# Altium schematic sheet RP5_Left_Board.SchDoc(RP5_Left_Board)
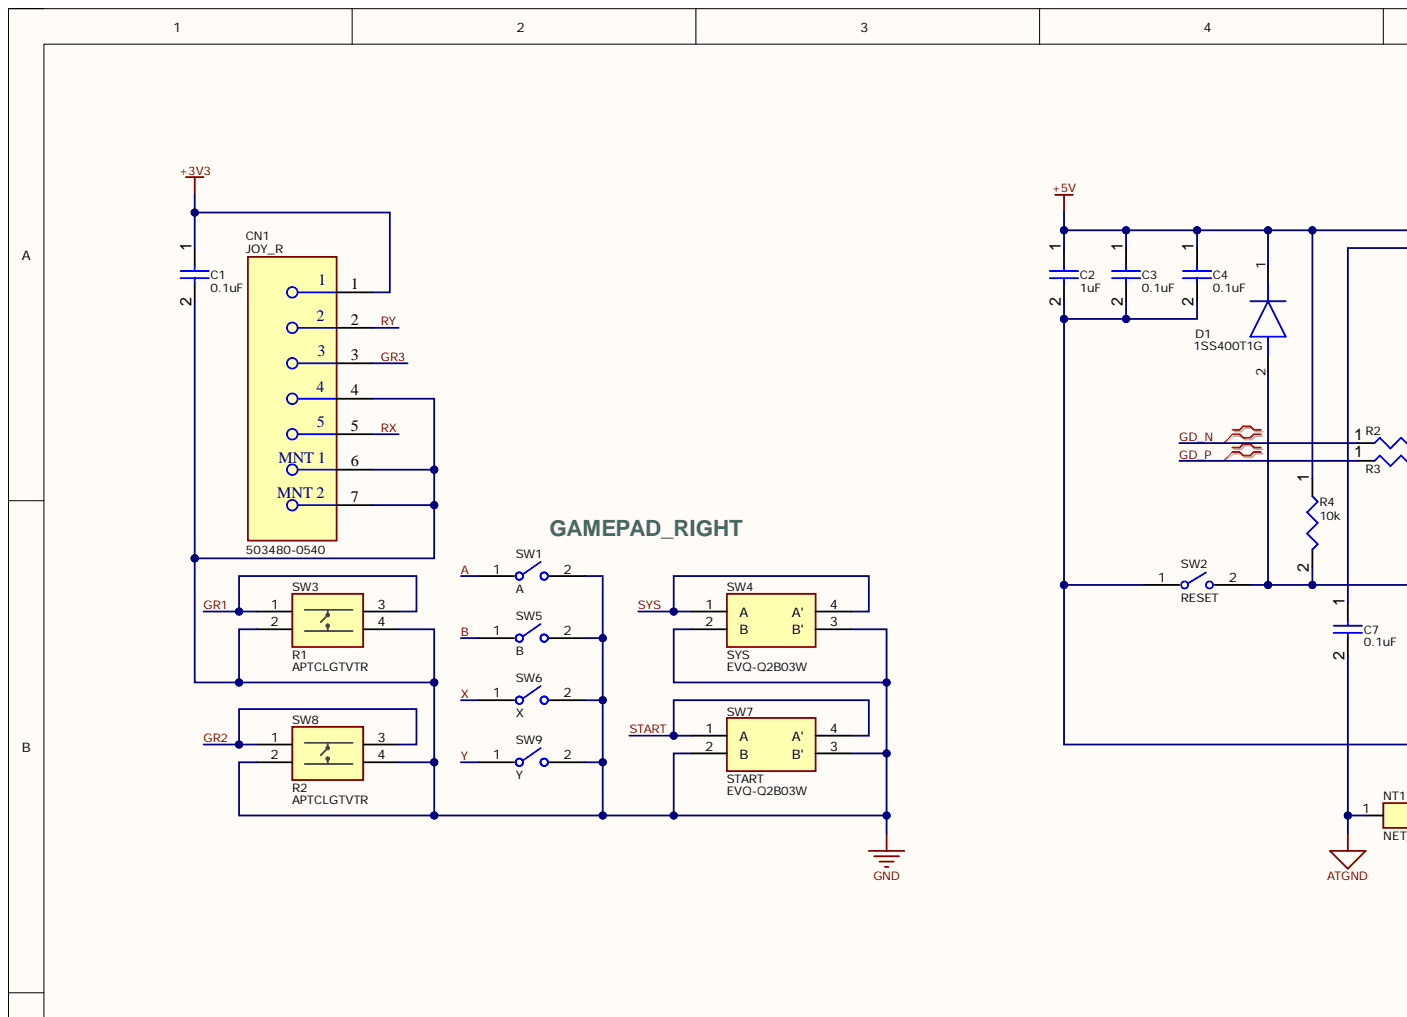
[diagram p0: RP5_Left_Board.SchDoc, top left]
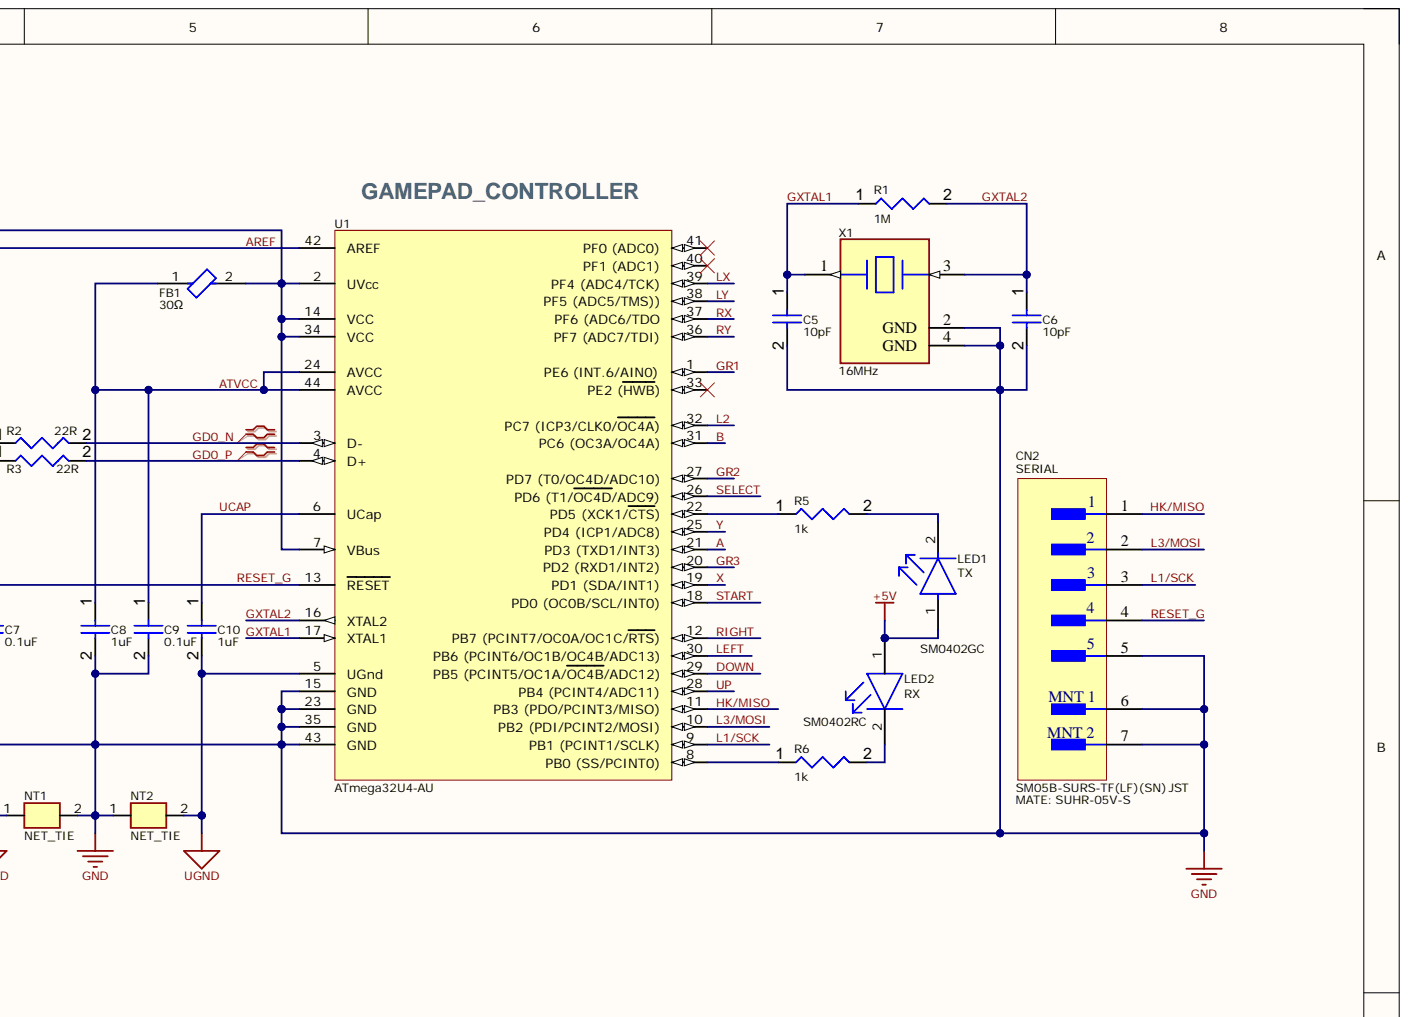
[diagram p1: RP5_Left_Board.SchDoc, top right]
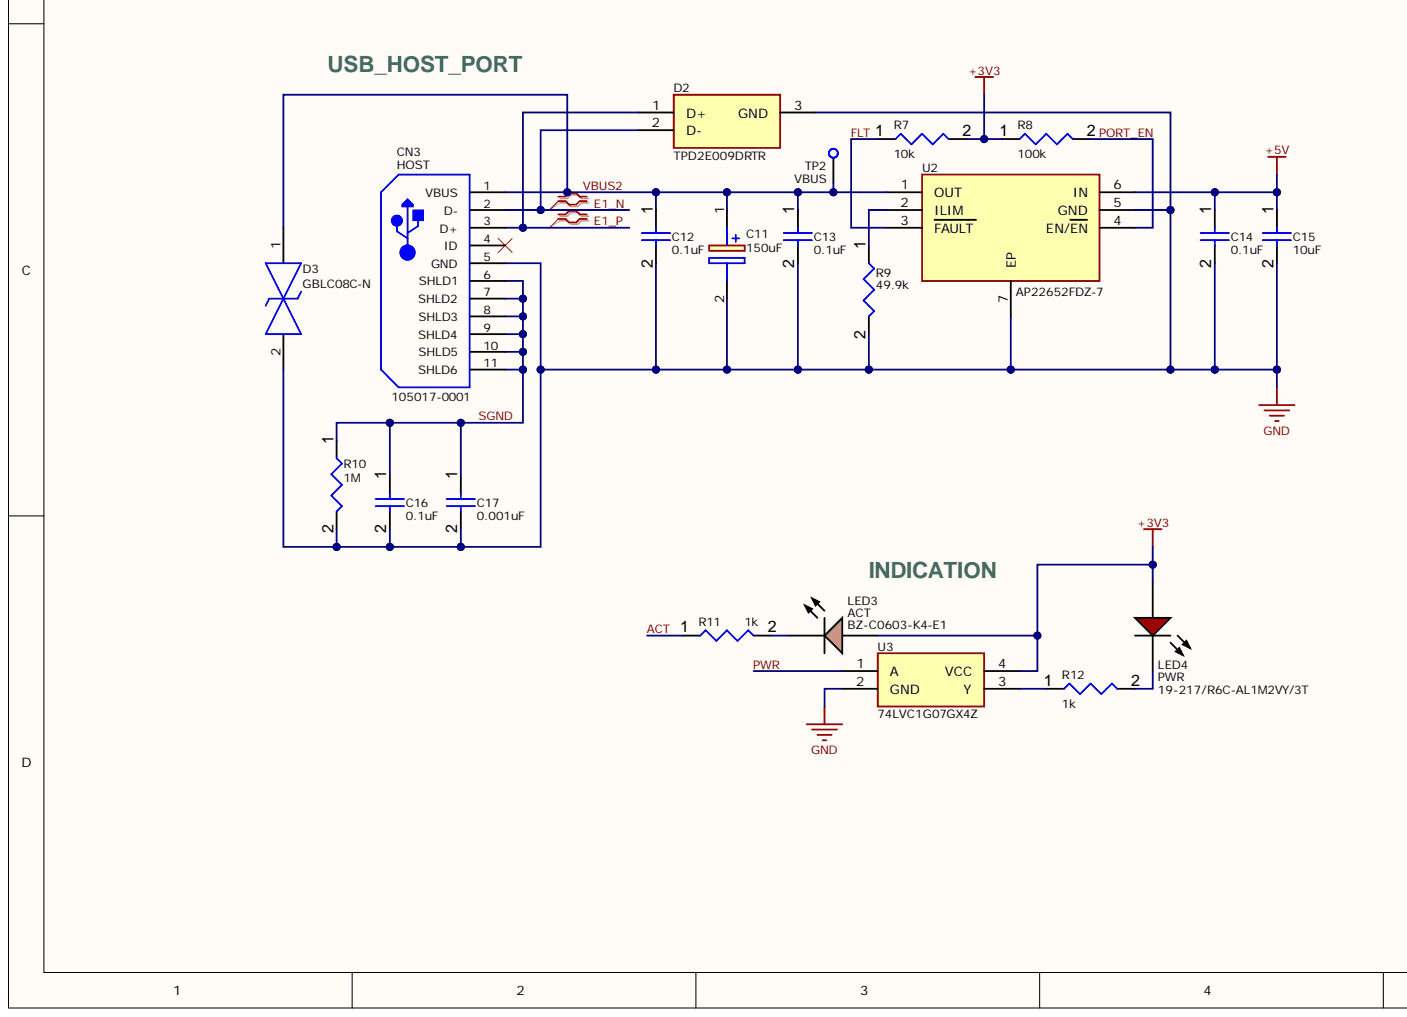
[diagram p2: RP5_Left_Board.SchDoc, bottom left]
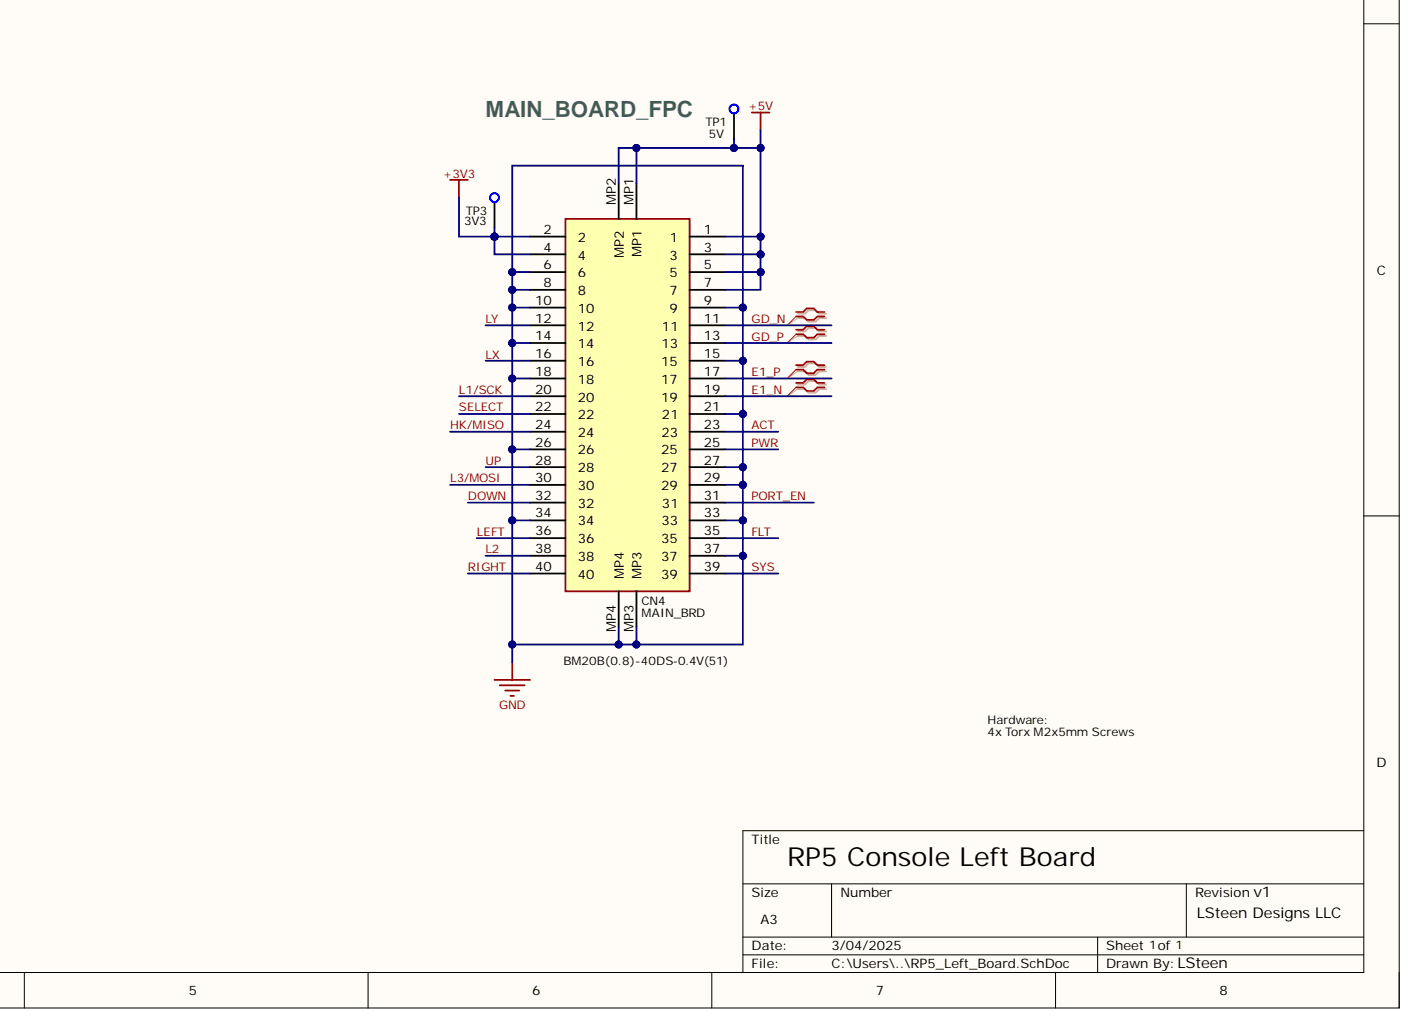
[diagram p3: RP5_Left_Board.SchDoc, bottom right]

## Parts
C1  0.1uF  [CAPC1005X56X25LL10T15]
C2  1uF  [CAPC0603(1608)100_L]
C3  0.1uF  [CAPC1005X56X25LL10T15]
C4  0.1uF  [CAPC1005X56X25LL10T15]
C5  10pF  [CAPC1005X56X25LL10T15]
C6  10pF  [CAPC1005X56X25LL10T15]
C7  0.1uF  [CAPC1005X56X25LL10T15]
C8  1uF  [CAPC0603(1608)100_L]
C9  0.1uF  [CAPC1005X56X25LL10T15]
C10  1uF  [CAPC0603(1608)100_L]
C11  150uF  [CAPPM7344X310N]
C12  0.1uF  [CAPC1005X56X25LL10T15]
C13  0.1uF  [CAPC1005X56X25LL10T15]
C14  0.1uF  [CAPC1005X56X25LL10T15]
C15  10uF  [CAPC0805(2012)145_L]
C16  0.1uF  [CAPC1005X56X25LL10T15]
C17  0.001uF  value 0.1uF  [CAPC1005X56X25LL10T15]
CN1  JOY_R  [FP-503480-0540-MFG]
CN2  SERIAL  [FP-SM05B-SURS-TF_LF_SN-MFG]
CN3  HOST  [105017-0001]
CN4  MAIN_BRD  [BM20B0840DS04V51]
D1  1SS400T1G  [SODFL1608X70N]
D2  TPD2E009DRTR  [SOTFL35P100X50-3N]
D3  GBLC08C-N  [SOD-323_L1.8-W1.3-LS2.5-BI]
FB1  30Ω  [FP-MH2029-MFG]
LED1  TX  [FP-SM0402GC-MFG]
LED2  RX  [FP-SM0402RC-MFG]
LED3  ACT  [LED_0603]
LED4  PWR  Manufacturer Part Number: 19-217/R6C-AL1M2VY/3T  [EVRL-0603-2_V]
NT1  NET_TIE  [NET_TIE1]
NT2  NET_TIE  [NET_TIE1]
R1  1M  [RESC0402(1005)_L]
R2  22R  [RESC0402(1005)_L]
R3  22R  [RESC0402(1005)_L]
R4  10k  [RESC0402(1005)_L]
R5  1k  [RESC0402(1005)_L]
R6  1k  [RESC0402(1005)_L]
R7  10k  [RESC0402(1005)_L]
R8  100k  [RESC0402(1005)_L]
R9  49.9k  [RESC0402(1005)_L]
R10  1M  [RESC0402(1005)_L]
R11  1k  [RESC0402(1005)_L]
R12  1k  [RESC0402(1005)_L]
SW1  A  [L4.0-W4.0-CNDV-PAD]
SW2  RESET  [FP-B3U-1000P-MFG]
SW3  R1  [APTCLGTVTR]
SW4  SYS  [EVQQ2]
SW5  B  [L4.0-W4.0-CNDV-PAD]
SW6  X  [L4.0-W4.0-CNDV-PAD]
SW7  START  [EVQQ2]
SW8  R2  Manufacturer_Part_Number: APTCLGTVTR  [APTCLGTVTR_No_Holes]
SW9  Y  [L4.0-W4.0-CNDV-PAD]
TP1  5V  [TP]  (no BOM)
TP2  VBUS  [TP]  (no BOM)
TP3  3V3  [TP]  (no BOM)
U1  ATmega32U4-AU  [44ML_M]
U2  AP22652FDZ-7  [SON65P200X200X80-7N-D]
U3  74LVC1G07GX4Z  [74LVC1G07GX4Z]
X1  16MHz  Load Capacitance: 10pF  [FP-ABM8G-MFG]

## Nets
+3V3: C1.1 CN1.1 CN4.2 CN4.4 LED3.1 LED4.1 R7.2 R8.1 TP3.1 U3.4(VCC)
+5V: C2.1 C3.1 C4.1 C14.1 C15.1 CN4.1 CN4.3 CN4.5 CN4.7 CN4.MP1 CN4.MP2 D1.1 FB1.2 LED1.1 LED2.1 R4.1 TP1.1 U1.2(UVcc) U1.7(VBus) U1.14(VCC) U1.34(VCC) U2.6(IN)
ATGND: C7.2 NT1.1
GND: C1.2 C2.2 C3.2 C4.2 C5.2 C6.2 C8.2 C9.2 C11.2 C12.2 C13.2 C14.2 C15.2 C16.2 C17.2 CN1.4 CN1.6 CN1.7 CN2.5 CN2.6 CN2.7 CN3.5(GND) CN4.6 CN4.8 CN4.9 CN4.10 CN4.14 CN4.15 CN4.18 CN4.21 CN4.26 CN4.27 CN4.29 CN4.33 CN4.34 CN4.37 CN4.MP3 CN4.MP4 D2.3 D3.2 NT1.2 NT2.1 R9.2 R10.2 SW1.2 SW2.1 SW3.2 SW3.4 SW4.2(B) SW4.3(B') SW5.2 SW6.2 SW7.2(B) SW7.3(B') SW8.2 SW8.4 SW9.2 U1.15(GND) U1.23(GND) U1.35(GND) U1.43(GND) U2.5(GND) U2.7(EP) U3.2(GND) X1.2(GND) X1.4(GND)
UGND: C10.2 NT2.2 U1.5(UGnd)
A: SW1.1 U1.21(PD3_(TXD1/INT3))
ACT: CN4.23 R11.1
AREF: C7.1 U1.42(AREF)
ATVCC: C8.1 C9.1 FB1.1 U1.24(AVCC) U1.44(AVCC)
B: SW5.1 U1.31(PC6_(OC3A/OC4A))
DOWN: CN4.32 U1.29
E1_N: CN3.2(D-) CN4.19 D2.2
E1_P: CN3.3(D+) CN4.17 D2.1
FLT: CN4.35 R7.1 U2.3(FAULT)
GD0_N: R2.2 U1.3(D-)
GD0_P: R3.2 U1.4(D+)
GD_N: CN4.11 R2.1
GD_P: CN4.13 R3.1
GR1: SW3.1 SW3.3 U1.1(PE6_(INT.6/AIN0))
GR2: SW8.1 SW8.3 U1.27(PD7_(T0/OC4D/ADC10))
GR3: CN1.3 U1.20(PD2_(RXD1/INT2))
GXTAL1: C5.1 R1.1 U1.17(XTAL1) X1.1
GXTAL2: C6.1 R1.2 U1.16(XTAL2) X1.3
HK/MISO: CN2.1 CN4.24 U1.11(PB3_(PDO/PCINT3/MISO))
L1/SCK: CN2.3 CN4.20 U1.9(PB1_(PCINT1/SCLK))
L2: CN4.38 U1.32(PC7_(ICP3/CLK0/OC4A))
L3/MOSI: CN2.2 CN4.30 U1.10(PB2_(PDI/PCINT2/MOSI))
LEFT: CN4.36 U1.30(PB6_(PCINT6/OC1B/OC4B/ADC13))
LX: CN4.16 U1.39(PF4_(ADC4/TCK))
LY: CN4.12 U1.38(PF5_(ADC5/TMS)))
PORT_EN: CN4.31 R8.2 U2.4(EN/EN)
PWR: CN4.25 U3.1(A)
RESET_G: CN2.4 D1.2 R4.2 SW2.2 U1.13(RESET)
RIGHT: CN4.40 U1.12
RX: CN1.5 U1.37(PF6_(ADC6/TDO)
RY: CN1.2 U1.36(PF7_(ADC7/TDI))
SELECT: CN4.22 U1.26(PD6_(T1/OC4D/ADC9))
SGND: C16.1 C17.1 CN3.6(SHLD1) CN3.7(SHLD2) CN3.8(SHLD3) CN3.9(SHLD4) CN3.10(SHLD5) CN3.11(SHLD6) R10.1
START: SW7.1(A) SW7.4(A') U1.18(PD0_(OC0B/SCL/INT0))
SYS: CN4.39 SW4.1(A) SW4.4(A')
UCAP: C10.1 U1.6(UCap)
UP: CN4.28 U1.28(PB4_(PCINT4/ADC11))
VBUS2: C11.1 C12.1 C13.1 CN3.1(VBUS) D3.1 TP2.1 U2.1(OUT)
X: SW6.1 U1.19(PD1_(SDA/INT1))
Y: SW9.1 U1.25(PD4_(ICP1/ADC8))
NetLED1_2: LED1.2 R5.2
NetLED2_2: LED2.2 R6.2
NetLED3_2: LED3.2 R11.2
NetLED4_2: LED4.2 R12.2
NetR5_1: R5.1 U1.22(PD5_(XCK1/CTS))
NetR6_1: R6.1 U1.8(PB0_(SS/PCINT0))
NetR9_1: R9.1 U2.2(ILIM)
NetR12_1: R12.1 U3.3(Y)

Not connected: CN3 4(ID); U1 33(PE2_(HWB)) 40(PF1_(ADC1)) 41(PF0_(ADC0))

## Library data of the parts
C1 C3 C4 C7 C9 C12-C14 C16 C17 = CMP-001-00001-6: Chip Capacitor, 0.1 uF, +/- 5%, 16 V, 0402 (1005 Metric); Capacitor Type: Ceramic; Voltage Rating: 16V; Case/Package: 0402; Component Kind: Standard; Max Operating Temperature: 125°C; Min Operating Temperature: -55°C; Mounting Technology: SM; Pins: 2; RoHS Compliant: True; Tolerance: 5%; Library Name: Colorado Technical University
  C1 C3 C4 C7 C9 C12-C14 C16: Value: 0.1uF
C2 C8 C10 = CMP-001-00076-4: Chip Capacitor, 1uF +/-20%, 10V, 0603, Thickness 1 mm; Tolerance: 10%; Case/Package: 0603; Component Kind: Standard; Max Operating Temperature: 85°C; Min Operating Temperature: -55°C; Mounting Technology: SMT; Pins: 2; Value: 1uF; Voltage Rating: 10V; Library Name: Colorado Technical University
C5 C6 = CMP-001-00001-6: Chip Capacitor, 10 pF, +/- 5%, 16 V, 0402 (1005 Metric); Capacitor Type: Ceramic; Voltage Rating: 16V; Case/Package: 0402; Component Kind: Standard; Max Operating Temperature: 125°C; Min Operating Temperature: -55°C; Mounting Technology: SM; Pins: 2; RoHS Compliant: True; Tolerance: 5%; Value: 10pF; Library Name: Colorado Technical University
C11 = TPSD157K016S0125: Capacitor Polarised; Manufacturer_Name: AVX; Arrow Part Number: TPSD157K016S0125; Arrow Price/Stock: https://www.arrow.com/en/products/tajd157k016rnjv/avx; Component Kind: Standard; Datasheet Link: http://datasheets.avx.com/TAJ.pdf; Height: 3.1mm; Library Name: SamacSys.SchLib; Manufacturer_Part_Number: TPSD157K016S0125; Mouser Part Number: 581-TPSD157K016S0125; Mouser Price/Stock: https://www.mouser.co.uk/ProductDetail/AVX/TAJD157K016RNJV?qs=%252BZP6%2F%252BtExtCxiQ2tCar1OQ%3D%3D; Supplier 1: Mouser; Supplier Part Number 1: 581-TPSD157K016S0125
C15 = CMP-001-00079-4: Chip Capacitor, 10µF +/-20%, 25V, 0805, Thickness 1.45 mm; Tolerance: 10%; Case/Package: 0805; Component Kind: Standard; Max Operating Temperature: 85°C; Min Operating Temperature: -55°C; Mounting Technology: SMT; Pins: 2; Value: 10µF; Voltage Rating: 25V; Library Name: Colorado Technical University
CN1 = CMP-00257-52963780-1: 503480-0540 Connector FFC/FPC SKT 5 Position 0.5mm Solder Right Angle Surface Mount T/R; Manufacturer Lifecycle Status: ACTIVE; Actuator Material: Glass, Nylon, Polyamide; Current Rating: 500mA; Number of Circuits: 5; Pitch: 508µm; Plating: Gold; Voltage Rating: 50V; Wire/Cable Type: Straight; Component Kind: Standard; Contact Material: Bronze, Gold, Nickel; Contact Plating: Gold; Country of Origin: KR; Flammability Rating: UL94 V-0; Halogen Free: Low Halogen; Height: 2.07mm; Housing Material: Polymer; Library Name: Altium Content Vault; Lifecycle Status: Production; Max Operating Temperature: 85°C; Min Operating Temperature: -40°C; Mount: Surface Mount; NexarPartId: 52963780; Number of Contacts: 5; Number of Positions: 5; Number of Rows: 1; Orientation: Right Angle; RoHS: Compliant; Termination: Solder
CN2 = CMP-17439-000553-2: CONN HEADER SMD R/A 5POS 0.8MM; Current Rating: 500mA; Number of Contacts: 5; Pitch: 800µm; Voltage Rating: 30V; Case/Package: SMD/SMT; Component Kind: Standard; Contact Material: Copper, Tin; Height: 1.75mm; Lead Free: Lead Free; Length: 6.2mm; Library Name: Altium Content Vault; Max Operating Temperature: 85°C; Min Operating Temperature: -25°C; Number of Poles: 5; Number of Rows: 1; RoHS: Compliant; Width: 2.7mm
CN3 = 105017-0001: Micro USB Connector; Manufacturer_Name: Molex; Component Kind: Standard; Library Name: SamacSys.SchLib; Manufacturer_Part_Number: 105017-0001; Mouser Part Number: 538-105017-0001; Supplier 1: Mouser; Supplier 2: Digikey; Supplier Part Number 1: 538-105017-0001; Supplier Part Number 2: WM1399CT-ND
CN4 = BM20B(0.8)-40DS-0.4V(51): Connector; Manufacturer_Name: Hirose; Component Kind: Standard; Datasheet Link: https://datasheet.datasheetarchive.com/originals/distributors/Datasheets-DGA17/1065509.pdf; Height: 0.9mm; Library Name: SamacSys.SchLib; Manufacturer_Part_Number: BM20B(0.8)-40DS-0.4V(51); Mouser Part Number: 798-BM20B0840DS04V51; Mouser Price/Stock: https://www.mouser.co.uk/ProductDetail/Hirose-Connector/BM20B0.8-40DS-0.4V51?qs=AAveGqk956F9p8KJryTUVg%3D%3D; Supplier 1: Mouser; Supplier Part Number 1: 798-BM20B0840DS04V51; System: Connector
D1 = 1SS400T1G: Diode; Manufacturer_Name: onsemi; Arrow Part Number: 1SS400T1G; Arrow Price/Stock: https://www.arrow.com/en/products/1ss400t1g/on-semiconductor?region=nac; Component Kind: Standard; Datasheet Link: http://www.onsemi.com/pub/Collateral/1SS400T1-D.PDF; Height: 0.7mm; Library Name: SamacSys.SchLib; Manufacturer_Part_Number: 1SS400T1G; Mouser Part Number: 863-1SS400T1G; Mouser Price/Stock: https://www.mouser.co.uk/ProductDetail/onsemi/1SS400T1G?qs=vLkC5FC1VN8%2F6DEK%2F5shrw%3D%3D; Supplier 1: Mouser; Supplier Part Number 1: 863-1SS400T1G
D2 = TPD2E009DRTR: Integrated Circuit; Manufacturer_Name: Texas Instruments; Arrow Part Number: TPD2E009DRTR; Arrow Price/Stock: https://www.arrow.com/en/products/tpd2e009drtr/texas-instruments?region=nac; Component Kind: Standard; Datasheet Link: https://www.ti.com/lit/ds/symlink/tpd2e009.pdf?ts=1621336941637&ref_url=https%253A%252F%252Fwww.google.com%252F; Height: 0.5mm; Library Name: SamacSys.SchLib; Manufacturer_Part_Number: TPD2E009DRTR; Mouser Part Number: 595-TPD2E009DRTR; Mouser Price/Stock: https://www.mouser.co.uk/ProductDetail/Texas-Instruments/TPD2E009DRTR?qs=XGzIaZb%2FFYLhG8oL%2FM05Rg%3D%3D; Supplier 1: Mouser; Supplier Part Number 1: 595-TPD2E009DRTR
D3 = GBLC08C-N: ESD DIODE-BI_LCSC#C314256; Component Kind: Standard; Library Name: Schlib1.SchLib
FB1 = CMP-07252-000001-1: FERRITE BEAD 30 OHM 0805 1LN; Manufacturer: Bourns; Manufacturer Part Number: MH2029-300Y; Impedance At Frequency: 30Ω @ 100MHz; Rms Current: 3A; Series Resistance: 25mΩ; Tolerance: 25%; Automotive: No; Category: FBead; Component Kind: Standard; ComponentLink2Description: Datasheet; ComponentLink2URL: https://www.bourns.com/docs/Product-Datasheets/mh.pdf; Core Material: Ferrite; Device Class L1: Passive Components; Device Class L2: EMI / RFI Components; Device Class L3: Ferrite Beads and Chips; Digikey Description: FERRITE BEAD 30 OHM 0805 1LN; Height: 1.1mm; Ipc Land Pattern Name: CAPC200120X90; Lead Free: Yes; Library Name: Altium Content Vault; Mouser Description: Ferrite Beads 30 ohms 25% HIGH CURRENT; Octopart: https://octopart.com/mh2029-300y-bourns-756929; Package: MH2029; Resistance: 30Ω; RoHS: TRUE; Temperature: -55°C to +125°C; Temperature Range High: +125°C; Temperature Range Low: -55°C; Value: 30Ω
LED1 = CMP-35283-000001-2: LED GREEN CLEAR 0402 SMD SUPPLIMENT LCSC MHT136CGCT; Dominant Wavelength: 572nm; Forward Voltage: 1.9V; Lens Color: Colorless; Lens Size: 1mm; Lens Style: Flat; Luminous Intensity: 28.5mcd; Test Current: 20mA; Viewing Angle: 130°; Case Code (Imperial): 0402; Case Code (Metric): 1005; Case/Package: 0402; Color: Green; Component Kind: Standard; Height: 0.71mm; Length: 1mm; Lens Transparency: Clear; Library Name: Altium Content Vault; Mount: Surface Mount; Packaging: Tape and Reel; Width: 0.5mm
LED2 = CMP-35283-000003-1: LED RED CLEAR 0402 SMD SUPPLIMENT LCSC MHT136CRCT; Dominant Wavelength: 631nm; Forward Voltage: 2.1V; Lens Color: Colorless; Lens Size: 1mm; Lens Style: Flat; Luminous Intensity: 90mcd; Test Current: 20mA; Viewing Angle: 130°; Case Code (Imperial): 0402; Case Code (Metric): 1005; Case/Package: 0402; Color: Red; Component Kind: Standard; Height: 0.71mm; Length: 1mm; Lens Transparency: Clear; Library Name: Altium Content Vault; Mount: Surface Mount; Packaging: Tape and Reel; Width: 0.5mm
LED3 = BZ-C0603-K4-E1: Component Kind: Standard; Library Name: SamacSys.SchLib; MPN: BZ-C0603-K4-E1
LED4 = CMP-2100-03578-1: Chip LED, Red, 5 V, 25 mA, -40 to 85 degC, 2-Pin SMD (0603), RoHS, Tape and Reel; Manufacturer: Everlight Electronics; Channels: 1; Forward Current: 25mA; Forward Voltage: 2.2V; Lens Style: Clear; Luminous Intensity-Nom: 20mcd; Optoelectronic Device Type: SINGLE COLOR LED; Overall Height: 0.4mm; Shape: Rectangular; Viewing Angle: 120°; Case/Package: 0603; Category: LEDs; Color: Red; Colour: Red; Component Kind: Standard; ComponentLink1Description: https://datasheet.ciiva.com:443/pdfs/VipMasterIC/IC/EVER/EVER-S-A0007433843/EVER-S-A0007433843-1.pdf?src-supplier=IHS+Markit; ComponentLink1URL: https://datasheet.ciiva.com:443/pdfs/VipMasterIC/IC/EVER/EVER-S-A0007433843/EVER-S-A0007433843-1.pdf?src-supplier=IHS+Markit; ComponentLink2Description: https://media.digikey.com/pdf/Data%20Sheets/Everlight%20PDFs/19-217-R6C-AL1M2VY-3T.pdf?src-supplier=Digikey; ComponentLink2URL: https://media.digikey.com/pdf/Data%20Sheets/Everlight%20PDFs/19-217-R6C-AL1M2VY-3T.pdf?src-supplier=Digikey; Datasheet URL: https://datasheet.ciiva.com/4688/el-19-217-r6c-al1m2vy-3tpdf-187026-4688713.pdf; Datasheet Version: Rev. 1, 01/2005; Lead Free: LeadFree; Library Name: Altium Content Vault; Manufacturer URL: http://www.everlight.com/; Max Operating Temperature: 85°C; Min Operating Temperature: -40°C; Mounting Technology: Surface Mount; Package Description: 2-Pin Surface Mount Device, Body 1.6 x 0.8 mm; Package Reference: 0603; Packaging: Tape and Reel; Pins: 2; Reach SVHC Compliant: Yes; RoHS: Yes; RoHS Compliant: Yes; RoHSCompliant: false; Size: 1mm; Supplier: Digikey; Supplier Part Number: 1080-1585-6-ND; Terminal Pitch: 1.5mm
R1 R10 = CMP-009-00138-3: Chip Resistor, 1 MOhms, +/-5 %, 63 mW, -55 to 155 degC, 0402; Power: 63mW; Tolerance: 5%; Case/Package: 0402; Component Kind: Standard; Max Operating Temperature: 155°C; Min Operating Temperature: -55°C; Pins: 2; Value: 1M; Library Name: Colorado Technical University
R2 R3 = CMP-009-00138-3: Chip Resistor, 22 Ohms, +/-5 %, 63 mW, -55 to 155 degC, 0402; Power: 63mW; Tolerance: 5%; Case/Package: 0402; Component Kind: Standard; Max Operating Temperature: 155°C; Min Operating Temperature: -55°C; Pins: 2; Value: 22R; Library Name: Colorado Technical University
R4 R7 = CMP-009-00138-3: Chip Resistor, 10 kOhms, +/-5 %, 63 mW, -55 to 155 degC, 0402; Power: 63mW; Tolerance: 5%; Case/Package: 0402; Component Kind: Standard; Max Operating Temperature: 155°C; Min Operating Temperature: -55°C; Pins: 2; Value: 10k; Library Name: Colorado Technical University
R5 R6 R11 R12 = CMP-009-00138-3: Chip Resistor, 1 kOhms, +/-5 %, 63 mW, -55 to 155 degC, 0402; Power: 63mW; Tolerance: 5%; Case/Package: 0402; Component Kind: Standard; Max Operating Temperature: 155°C; Min Operating Temperature: -55°C; Pins: 2; Value: 1k; Library Name: Colorado Technical University
R8 = CMP-009-00138-3: Chip Resistor, 100 kOhms, +/-5 %, 63 mW, -55 to 155 degC, 0402; Power: 63mW; Tolerance: 5%; Case/Package: 0402; Component Kind: Standard; Max Operating Temperature: 155°C; Min Operating Temperature: -55°C; Pins: 2; Value: 100k; Library Name: Colorado Technical University
R9 = CMP-009-00138-3: Chip Resistor, 49.9 kOhms, +/-5 %, 63 mW, -55 to 155 degC, 0402; Power: 63mW; Tolerance: 5%; Case/Package: 0402; Component Kind: Standard; Max Operating Temperature: 155°C; Min Operating Temperature: -55°C; Pins: 2; Value: 49.9k; Library Name: Colorado Technical University
SW1 SW5 SW6 SW9 = CNDV_SW: Conductive Pad Switch; Component Kind: Standard; Library Name: Schlib1.SchLib
SW2 = CMP-2100-03586-2: LCSC SWITCH TACTILE SPST-NO 0.05A 12V; Actuator Length: 0.4mm; Actuator Type: Standard; Contact Current Rating: 50mA; Contact Resistance: 100mR; Electrical Life: 200000Cycles; Illumination Type: Non-Illuminated; Operating Force: 1.5N; Ratings: Dust Proof; Switch Function: Off-Mom; Switch Travel: 0.15mm; Throw Configuration: SPST; Component Kind: Standard; Contact Material: Silver; Current Rating: 50mA; Depth: 2.5mm; Height: 1.2mm; Insulation Resistance: 100MR; Lead Free: Lead Free; Length: 4mm; Library Name: Altium Content Vault; Max Current Rating: 50mA; Max Operating Temperature: 70°C; Max Voltage Rating (DC): 12V; Mechanical Life: 200000Cycles; Min Operating Temperature: -25°C; Mount: Surface Mount; Orientation: Straight; Package Quantity: 1; Packaging: Tape and Reel; Termination: SMD/SMT; Voltage Rating (DC): 12V; Weight: 0.000776oz; Width: 3mm
SW3 = APTCLGTVTR: Switch; Manufacturer_Name: Apem; Component Kind: Standard; Datasheet Link: https://media.digikey.com/pdf/Data%20Sheets/APEM%20Components%20PDFs/APTCLGTV.pdf; Height: 1.87mm; Library Name: SamacSys.SchLib; Manufacturer_Part_Number: APTCLGTVTR; Mouser Part Number: 642-APTCLGTVTR; Mouser Price/Stock: https://www.mouser.co.uk/ProductDetail/Apem/APTCLGTVTR?qs=ikKlZSMjbcRNf%252Bwzp31ctg%3D%3D
SW4 SW7 = EVQ-Q2B03W: Switch; Manufacturer_Name: Panasonic; Arrow Part Number: EVQ-Q2B03W; Arrow Price/Stock: https://www.arrow.com/en/products/evq-q2b03w/panasonic; Component Kind: Standard; Datasheet Link: https://industrial.panasonic.com/cdbs/www-data/pdf/ATK0000/ATK0000C360.pdf; Library Name: SamacSys.SchLib; Manufacturer_Part_Number: EVQ-Q2B03W; Mouser Part Number: 667-EVQ-Q2B03W; Mouser Price/Stock: https://www.mouser.co.uk/ProductDetail/Panasonic/EVQ-Q2B03W?qs=WwqriLBepZtuDDVMSV2X%252BA%3D%3D; Supplier 1: Mouser; Supplier Part Number 1: 667-EVQ-Q2B03W
SW8 = APTCLGTVTR_NH: Switch; Manufacturer_Name: Apem; Component Kind: Standard; Datasheet Link: https://media.digikey.com/pdf/Data%20Sheets/APEM%20Components%20PDFs/APTCLGTV.pdf; Height: 1.87mm; Library Name: SamacSys.SchLib; Mouser Part Number: 642-APTCLGTVTR; Mouser Price/Stock: https://www.mouser.co.uk/ProductDetail/Apem/APTCLGTVTR?qs=ikKlZSMjbcRNf%252Bwzp31ctg%3D%3D; Supplier 1: Mouser; Supplier Part Number 1: 642-APTCLGTVTR
TP1-TP3 = TestPoint: Description: TestPoint; Library Name: Schlib1.SchLib
U1 = CMP-0095-00285-2: 8-bit AVR Microcontroller, 2.7-5.5V, 16MHz, 32KB Flash, 1KB EEPROM, 2.5KB SRAM, USB Controller, 44-pin TQFP, Industrial Grade (-40°C to 85°C), Ext Osc; Component Kind: Standard; ComponentLink1Description: Manufacturer URL; ComponentLink1URL: http://www.atmel.com/; ComponentLink2Description: ATmega16U4/32U4 Datasheet; ComponentLink2URL: http://www.atmel.com/dyn/resources/prod_documents/doc7766.pdf; ComponentLink3Description: ATmega16U4 ATmega32U4 Summary; ComponentLink3URL: http://www.atmel.com/dyn/resources/prod_documents/7766S.pdf; DatasheetVersion: revF, Nov-2010; Library Name: Altium Content Vault; PackageDescription: 44-Pin Plastic Thin Quad Flat Pack, 10 x 10 x 1.0 mm Body, 0.8 mm Pitch (TQFP); PackageReference: 44ML; PackageVersion: rev.G, Jul-2007; RevisionNote: DatasheetVersion updated. URLs added.
U2 = AP22652FDZ-7: Integrated Circuit; Manufacturer_Name: Diodes Incorporated; Arrow Part Number: AP22652FDZ-7; Arrow Price/Stock: https://www.arrow.com/en/products/ap22652fdz-7/diodes-incorporated?region=nac; Component Kind: Standard; Datasheet Link: https://www.diodes.com//assets/Datasheets/AP22652_53_52A_53A.pdf; Height: 0.8mm; Library Name: SamacSys.SchLib; Manufacturer_Part_Number: AP22652FDZ-7; Mouser Part Number: 621-AP22652FDZ-7; Mouser Price/Stock: https://www.mouser.co.uk/ProductDetail/Diodes-Incorporated/AP22652FDZ-7?qs=rkhjVJ6%2F3EKN8%2FqxLFOecw%3D%3D; Supplier 1: Mouser; Supplier Part Number 1: 621-AP22652FDZ-7
U3 = 74LVC1G07GX4Z: Integrated Circuit; Manufacturer_Name: Nexperia; Arrow Part Number: 74LVC1G07GX4Z; Arrow Price/Stock: https://www.arrow.com/en/products/74lvc1g07gx4z/nexperia?region=nac; Component Kind: Standard; Datasheet Link: https://assets.nexperia.com/documents/data-sheet/74LVC1G07.pdf; Height: 0.35mm; Library Name: SamacSys.SchLib; Manufacturer_Part_Number: 74LVC1G07GX4Z; Mouser Part Number: 771-74LVC1G07GX4Z; Mouser Price/Stock: https://www.mouser.co.uk/ProductDetail/Nexperia/74LVC1G07GX4Z?qs=u16ybLDytRaaOC9%252BoslzEg%3D%3D; Supplier 1: Mouser; Supplier Part Number 1: 771-74LVC1G07GX4Z
X1 = CMP-27762-015167-1: Crystal 16MHz ±30ppm (Tol) ±30ppm (Stability) 10pF FUND 80Ω 4-Pin SMD T/R; ESR (Equivalent Series Resistance): 80R; Case/Package: CSMD; Component Kind: Standard; Depth: 2.5mm; Frequency: 16MHz; Frequency Stability: 30ppm; Frequency Tolerance: 30ppm; Height: 1mm; Lead Free: Lead Free; Length: 3.2mm; Library Name: Altium Content Vault; Max Operating Temperature: 70°C; Min Operating Temperature: -20°C; Mount: Surface Mount; Number of Pins: 4; Operating Frequency: 16 MHz; Package Quantity: 1000; Packaging: Tape and Reel; Series: ABM8G; Termination: SMD/SMT; Tolerance: 30ppm; Width: 2.5mm
NT1 NT2 = NET_TIE: Component Kind: Net Tie; Description: NET_TIE; Library Name: Schlib1.SchLib
